# Altium schematic sheet lora.SchDoc
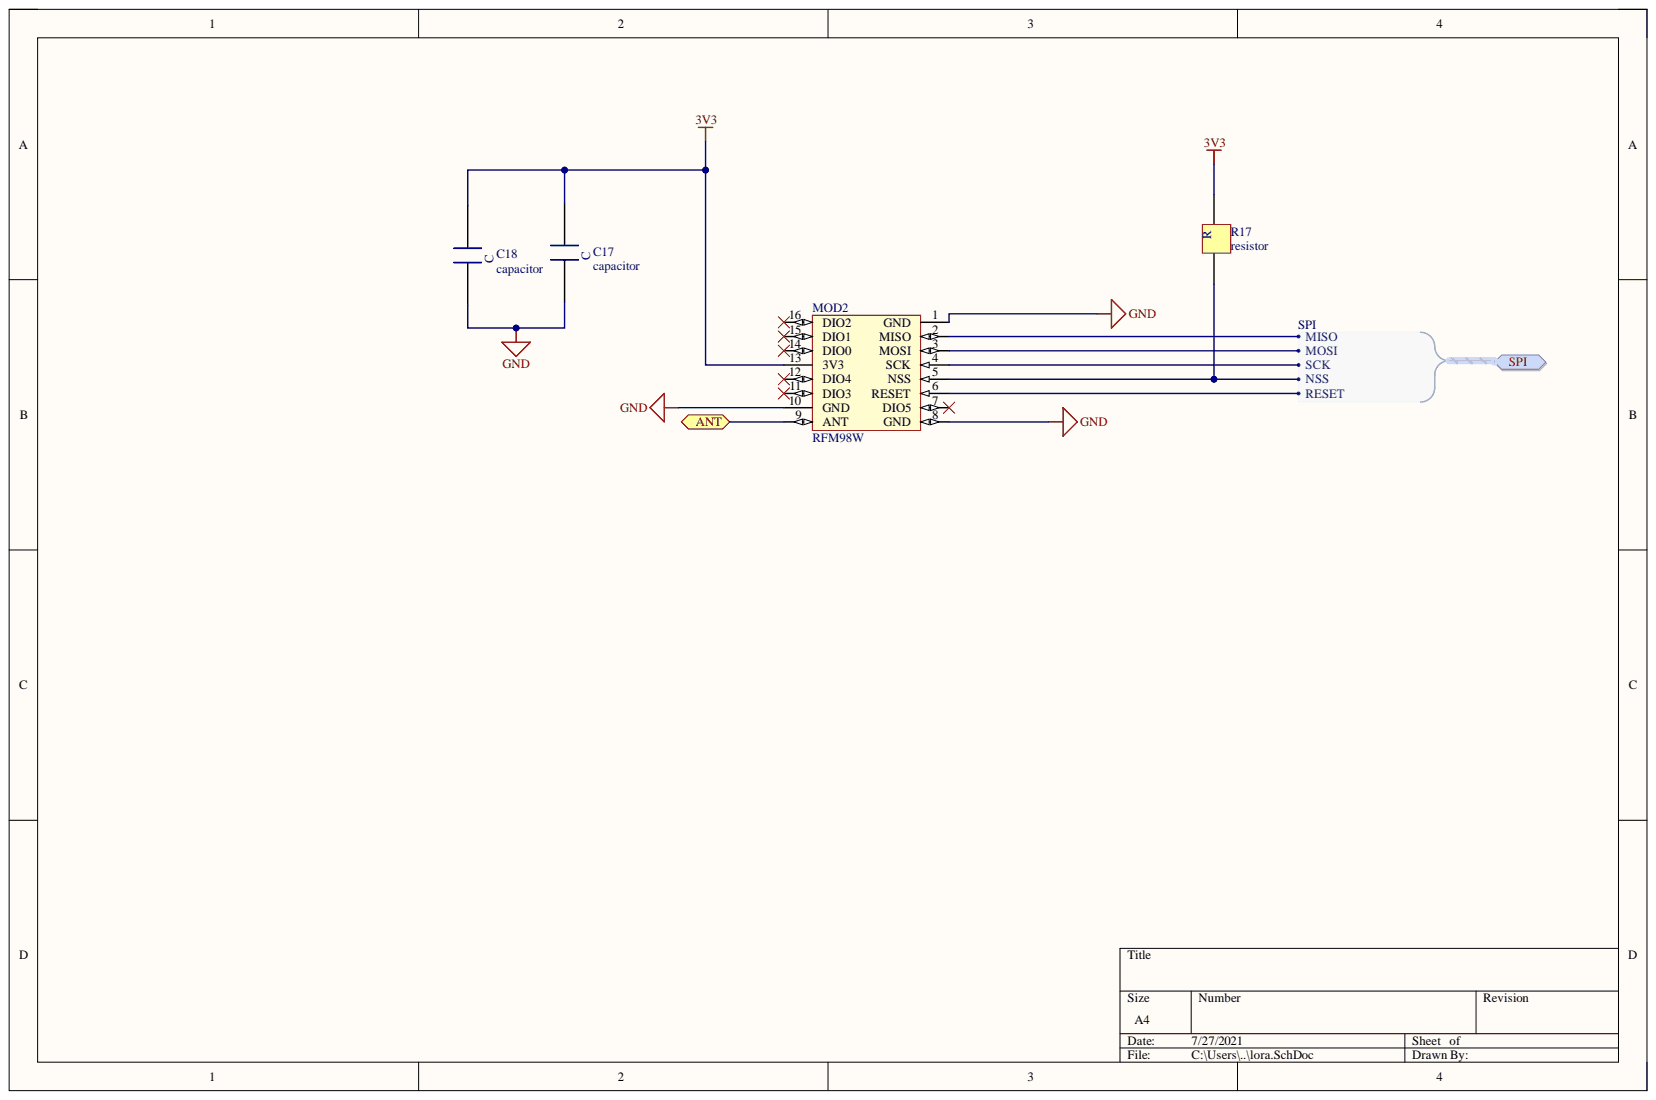
[diagram p0: lora.SchDoc]

## Parts
C17  capacitor  [CAPC1005X04L]
C18  capacitor  [CAPC1005X04L]
MOD2  RFM98W
R17  resistor  [CAPC1005X04L]

## Ports
ANT, SPI, SPI.MISO, SPI.MOSI, SPI.NSS, SPI.RESET, SPI.SCK

## Nets
3V3: C17.1 C18.1 MOD2.13(3V3) R17.1
GND: C17.2 C18.2 MOD2.1(GND) MOD2.8(GND) MOD2.10(GND)
ANT: MOD2.9(ANT) ; port ANT
NetMOD2_2: MOD2.2(MISO) ; port SPI
NetMOD2_3: MOD2.3(MOSI) ; port SPI
NetMOD2_4: MOD2.4(SCK) ; port SPI
NetMOD2_5: MOD2.5(NSS) R17.2 ; port SPI
NetMOD2_6: MOD2.6(RESET) ; port SPI
NetMOD2_7: MOD2.7(DIO5)
NetMOD2_11: MOD2.11(DIO3)
NetMOD2_12: MOD2.12(DIO4)
NetMOD2_14: MOD2.14(DIO0)
NetMOD2_15: MOD2.15(DIO1)
NetMOD2_16: MOD2.16(DIO2)

## Library data of the parts
C17 C18 = capacitor: Component Kind: Standard; Library Name: pasives.SchLib
MOD2 = RFM98W: Component Kind: Standard; Library Name: halfgelijders.SchLib
R17 = resistor: Component Kind: Standard; Library Name: pasives.SchLib
